# Altium schematic sheet 7  - Microcontoller.SchDoc("7  - Microcontoller")
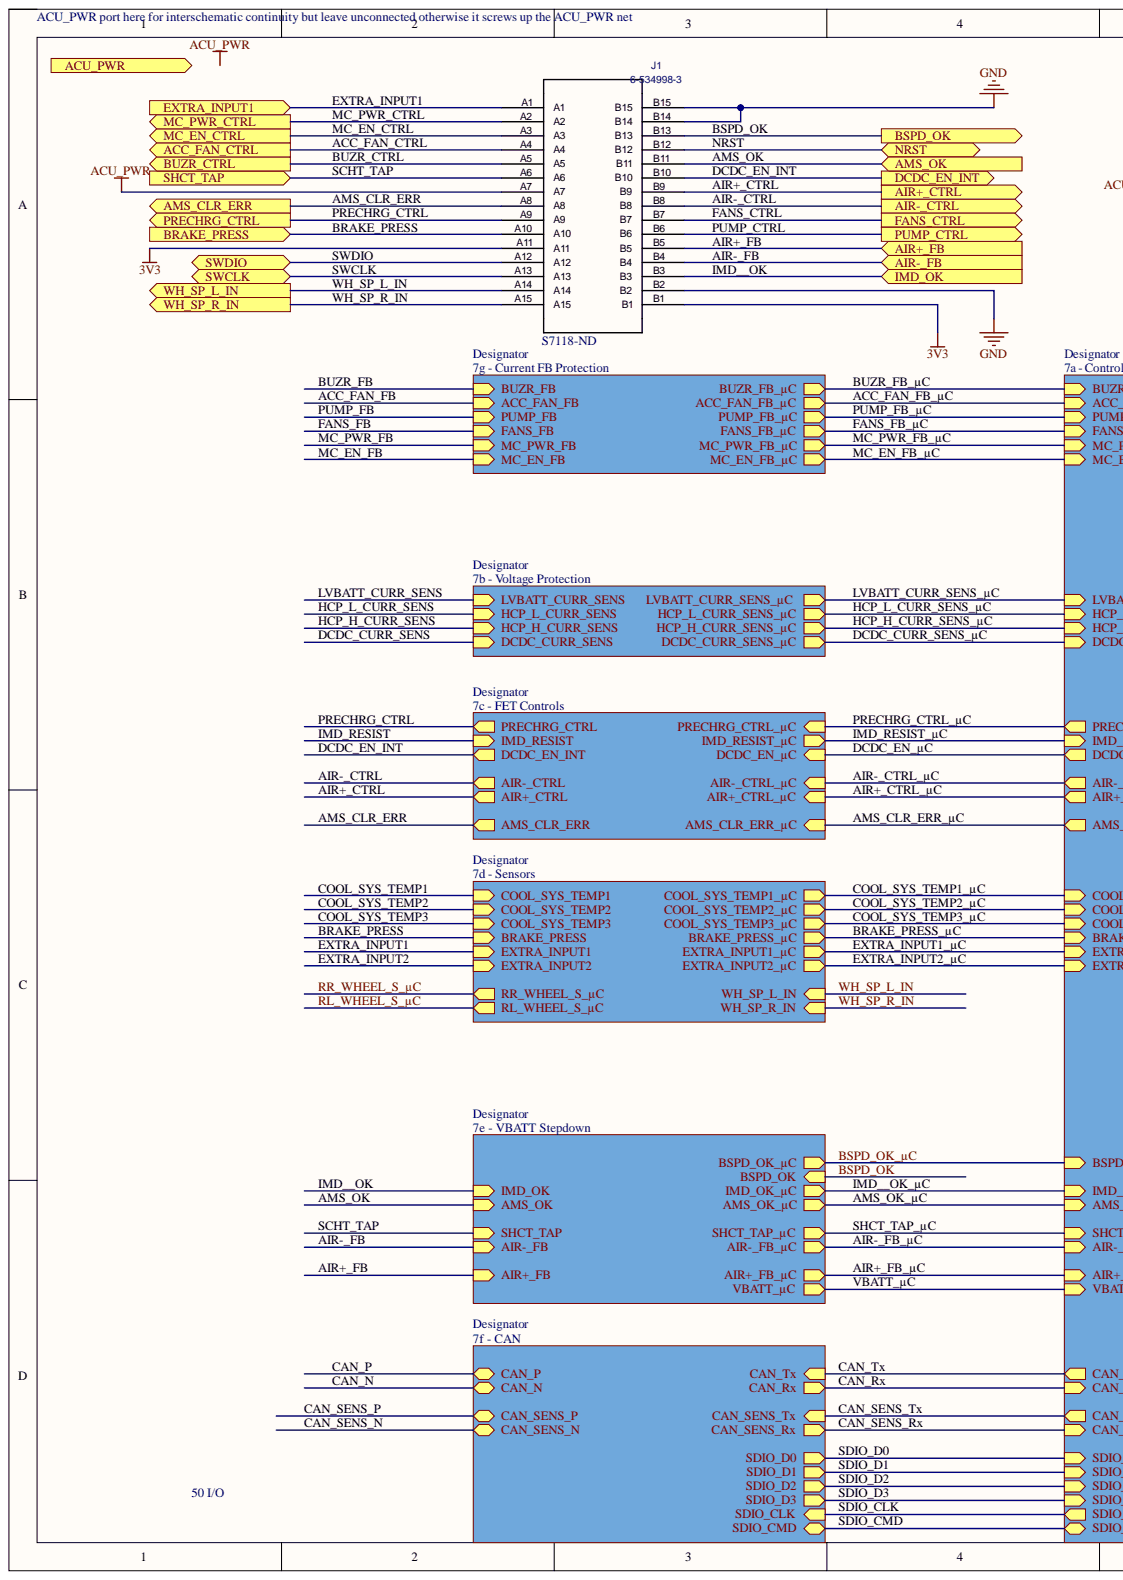
[diagram p0: 7  - Microcontoller.SchDoc, left]
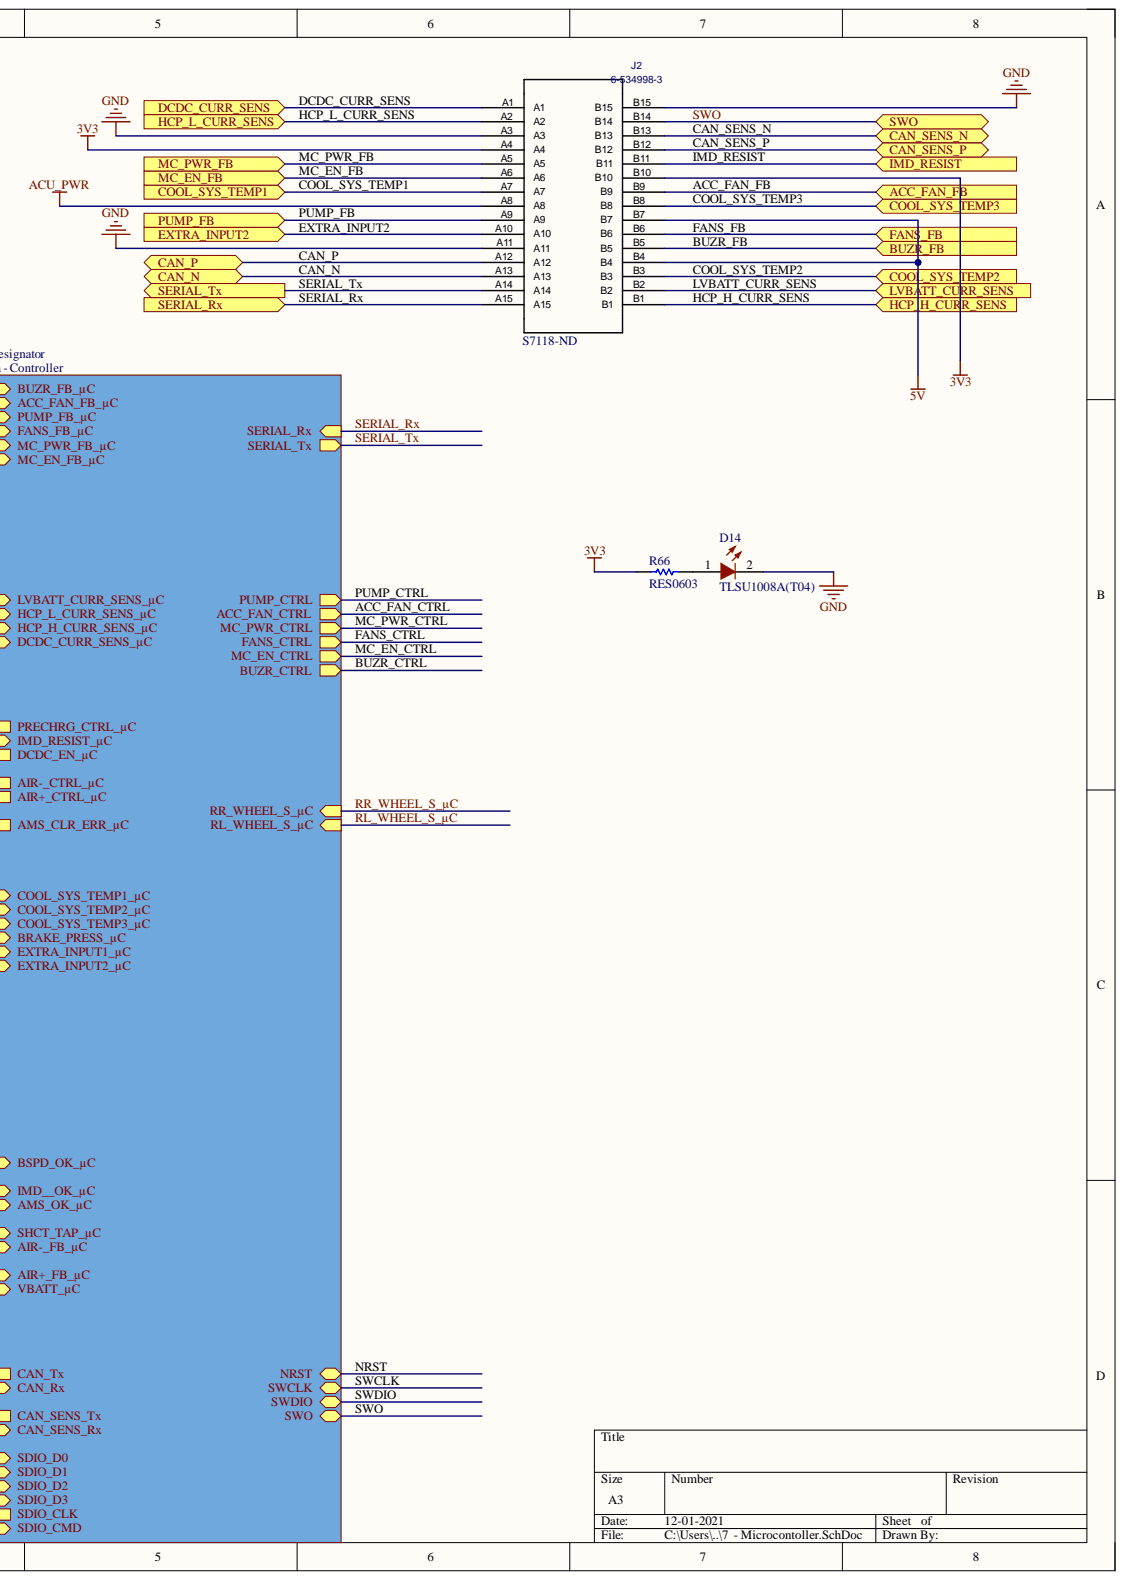
[diagram p1: 7  - Microcontoller.SchDoc, right]

## Parts
D14  TLSU1008A(T04)  [TOSH-0603LED_RED_V]
J1  6-534998-3  Supplier Part Number 1: S7118-ND  [6-534998-5_6-534998-5(Primary)]
J2  6-534998-3  Supplier Part Number 1: S7118-ND  [6-534998-5_6-534998-5(Primary)]
R66  RES0603  [RESC0603(1608)_L]

## Ports
ACC_FAN_CTRL, ACC_FAN_FB, ACU_PWR, AIR+_CTRL, AIR+_FB, AIR-_CTRL, AIR-_FB, AMS_CLR_ERR, AMS_OK, BRAKE_PRESS, BSPD_OK, BUZR_CTRL, BUZR_FB, CAN_N, CAN_P, CAN_SENS_N, CAN_SENS_P, COOL_SYS_TEMP1, COOL_SYS_TEMP2, COOL_SYS_TEMP3, DCDC_CURR_SENS, DCDC_EN_INT, EXTRA_INPUT1, EXTRA_INPUT2, FANS_CTRL, FANS_FB, HCP_H_CURR_SENS, HCP_L_CURR_SENS, IMD_OK, IMD_RESIST, LVBATT_CURR_SENS, MC_EN_CTRL, MC_EN_FB, MC_PWR_CTRL, MC_PWR_FB, NRST, PRECHRG_CTRL, PUMP_CTRL, PUMP_FB, SERIAL_Rx, SERIAL_Tx, SHCT_TAP, SWCLK, SWDIO, SWO, WH_SP_L_IN, WH_SP_R_IN

## Nets
3V3: J1.A11 J1.B1 J2.A4 J2.B10 R66.1
5V: J2.B4 J2.B7
ACU_PWR: J1.A7 J2.A8
GND: D14.2 J1.B2 J1.B14 J1.B15 J2.A3 J2.A11 J2.B15
ACC_FAN_CTRL: J1.A4 ; port ACC_FAN_CTRL
ACC_FAN_FB: J2.B9 ; port ACC_FAN_FB
AIR+_CTRL: J1.B9 ; port AIR+_CTRL
AIR+_FB: J1.B5 ; port AIR+_FB
AIR-_CTRL: J1.B8 ; port AIR-_CTRL
AIR-_FB: J1.B4 ; port AIR-_FB
AMS_CLR_ERR: J1.A8 ; port AMS_CLR_ERR
AMS_OK: J1.B11 ; port AMS_OK
BRAKE_PRESS: J1.A10 ; port BRAKE_PRESS
BSPD_OK: J1.B13 ; port BSPD_OK
BUZR_CTRL: J1.A5 ; port BUZR_CTRL
BUZR_FB: J2.B5 ; port BUZR_FB
CAN_N: J2.A13 ; port CAN_N
CAN_P: J2.A12 ; port CAN_P
CAN_SENS_N: J2.B13 ; port CAN_SENS_N
CAN_SENS_P: J2.B12 ; port CAN_SENS_P
COOL_SYS_TEMP1: J2.A7 ; port COOL_SYS_TEMP1
COOL_SYS_TEMP2: J2.B3 ; port COOL_SYS_TEMP2
COOL_SYS_TEMP3: J2.B8 ; port COOL_SYS_TEMP3
DCDC_CURR_SENS: J2.A1 ; port DCDC_CURR_SENS
DCDC_EN_INT: J1.B10 ; port DCDC_EN_INT
EXTRA_INPUT1: J1.A1 ; port EXTRA_INPUT1
EXTRA_INPUT2: J2.A10 ; port EXTRA_INPUT2
FANS_CTRL: J1.B7 ; port FANS_CTRL
FANS_FB: J2.B6 ; port FANS_FB
HCP_H_CURR_SENS: J2.B1 ; port HCP_H_CURR_SENS
HCP_L_CURR_SENS: J2.A2 ; port HCP_L_CURR_SENS
IMD__OK: J1.B3 ; port IMD_OK
IMD_RESIST: J2.B11 ; port IMD_RESIST
LVBATT_CURR_SENS: J2.B2 ; port LVBATT_CURR_SENS
MC_EN_CTRL: J1.A3 ; port MC_EN_CTRL
MC_EN_FB: J2.A6 ; port MC_EN_FB
MC_PWR_CTRL: J1.A2 ; port MC_PWR_CTRL
MC_PWR_FB: J2.A5 ; port MC_PWR_FB
NRST: J1.B12 ; port NRST
PRECHRG_CTRL: J1.A9 ; port PRECHRG_CTRL
PUMP_CTRL: J1.B6 ; port PUMP_CTRL
PUMP_FB: J2.A9 ; port PUMP_FB
SCHT_TAP: J1.A6 ; port SHCT_TAP
SERIAL_Rx: J2.A15 ; port SERIAL_Rx
SERIAL_Tx: J2.A14 ; port SERIAL_Tx
SWCLK: J1.A13 ; port SWCLK
SWDIO: J1.A12 ; port SWDIO
SWO: J2.B14 ; port SWO
WH_SP_L_IN: J1.A14 ; port WH_SP_L_IN
WH_SP_R_IN: J1.A15 ; port WH_SP_R_IN
NetD14_1: D14.1 R66.2
ACC_FAN_FB_µC:
AIR+_CTRL_µC:
AIR+_FB_µC:
AIR-_CTRL_µC:
AIR-_FB_µC:
AMS_CLR_ERR_µC:
AMS_OK_µC:
BRAKE_PRESS_µC:
BSPD_OK_µC:
BUZR_FB_µC:
CAN_Rx:
CAN_SENS_Rx:
CAN_SENS_Tx:
CAN_Tx:
COOL_SYS_TEMP1_µC:
COOL_SYS_TEMP2_µC:
COOL_SYS_TEMP3_µC:
DCDC_CURR_SENS_µC:
DCDC_EN_µC:
EXTRA_INPUT1_µC:
EXTRA_INPUT2_µC:
FANS_FB_µC:
HCP_H_CURR_SENS_µC:
HCP_L_CURR_SENS_µC:
IMD__OK_µC:
IMD_RESIST_µC:
LVBATT_CURR_SENS_µC:
MC_EN_FB_µC:
MC_PWR_FB_µC:
N00001: ; port ACU_PWR
PRECHRG_CTRL_µC:
PUMP_FB_µC:
RL_WHEEL_S_µC:
RR_WHEEL_S_µC:
SDIO_CLK:
SDIO_CMD:
SDIO_D0:
SDIO_D1:
SDIO_D2:
SDIO_D3:
SHCT_TAP_µC:
VBATT_µC:

## Library data of the parts
D14 = CMP-0444-00001-1: LED, Red 0603 (1608) 63mCd; Manufacturer: Toshiba; Forward Current: 25 mA; Packing Type: Reel; Power Dissipation: 60 mW; Reverse Voltage: 4 V; Component Kind: Standard; ComponentLink1Description: Manufacturer URL; ComponentLink1URL: http://www.toshiba-components.com/; ComponentLink2Description: Datasheet; ComponentLink2URL: http://www.semicon.toshiba.co.jp/info/docget.jsp?type=datasheet&lang=en&pid=TLSU1008A(T04); DatasheetVersion: Aug-08; Library Name: Altium Content Vault; Mounting Technology: Surface Mount; PackageDescription: 2-Pin SMT LED, 1.6 x 0.8 mm Pitch; PackageReference: 0603LED_RED; PartNumber: TLSU1008A(T04); Storage Temperature: -40 to 100 degC
J1 J2 = 6-534998-3: Built_By: EMA_UL_Team; C: Copyright (C) 2015 Accelerated Designs. All rights reserved; Vendor: TE Connectivity; Component Kind: Standard; Manufacturer_Part_Number: 6-534998-3; Supplier 1: Digi-Key; Value: 6-534998-3; Library Name: ePBR22_ACU_Controller.SCHLIB
R66 = CMP-1591-00001-1: 0603 (1608 Metric) Chip Resistor; Case-EIA: 0603; Case-Metric: 1608; Component Kind: Standard; Library Name: Altium Content Vault; PackageReference: RESC0603(1608)
